# Altium schematic sheet microcontroller.SchDoc(U_microcontroller)
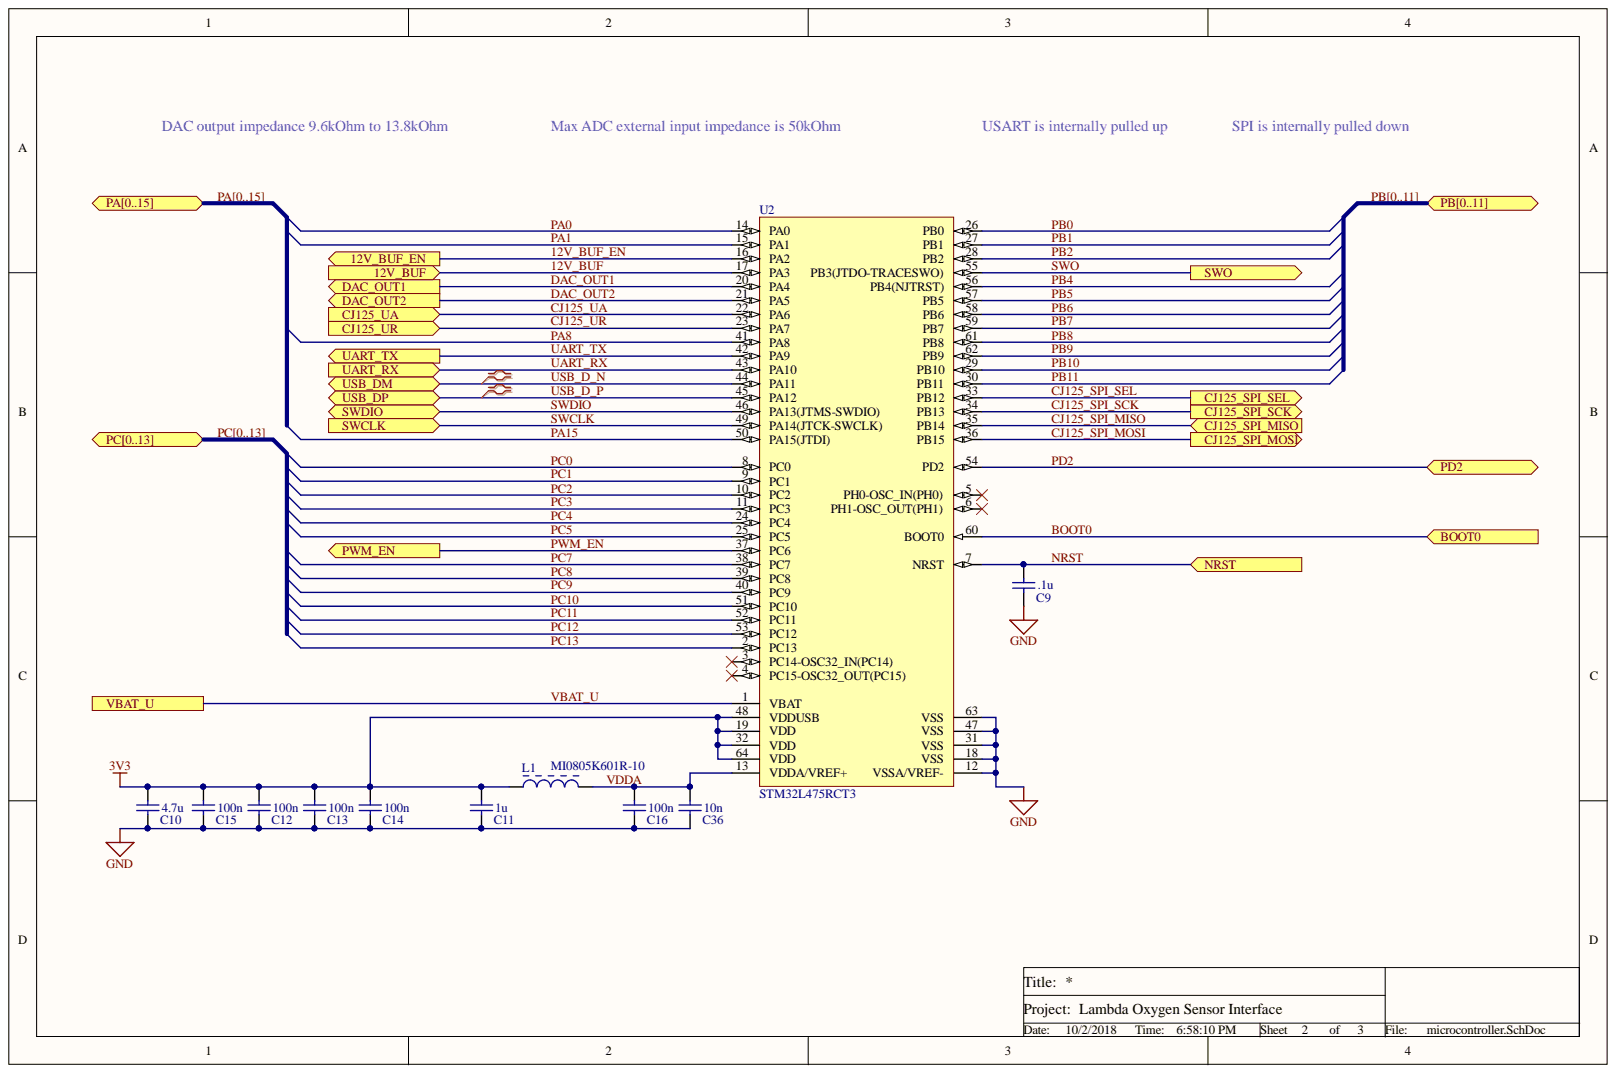
[diagram p0: microcontroller.SchDoc]

## Parts
C9  value .1u  [0805]  (hidden comment: Generic 0805 Capacitor)
C10  value 4.7u  [0805]  (hidden comment: Generic 0805 Capacitor)
C11  value 1u  [0805]  (hidden comment: Generic 0805 Capacitor)
C12  value 100n  [0805]  (hidden comment: Generic 0805 Capacitor)
C13  value 100n  [0805]  (hidden comment: Generic 0805 Capacitor)
C14  value 100n  [0805]  (hidden comment: Generic 0805 Capacitor)
C15  value 100n  [0805]  (hidden comment: Generic 0805 Capacitor)
C16  value 100n  [0805]  (hidden comment: Generic 0805 Capacitor)
C36  value 10n  [0805]  (hidden comment: Generic 0805 Capacitor)
L1  MI0805K601R-10  [LIRD-MI0805K601R-10-2_V]
U2  STM32L475RCT3  [STM-LQFP64_L]

## Ports
12V_BUF, 12V_BUF_EN, BOOT0, CJ125_SPI_MISO, CJ125_SPI_MOSI, CJ125_SPI_SCK, CJ125_SPI_SEL, CJ125_UA, CJ125_UR, DAC_OUT1, DAC_OUT2, NRST, PA0, PA1, PA2, PA3, PA4, PA5, PA6, PA7, PA8, PA9, PA10, PA11, PA12, PA13, PA14, PA15, PA[0..15], PB0, PB1, PB2, PB3, PB4, PB5, PB6, PB7, PB8, PB9, PB10, PB11, PB[0..11], PC0, PC1, PC2, PC3, PC4, PC5, PC6, PC7, PC8, PC9, PC10, PC11, PC12, PC13, PC[0..13], PD2, PWM_EN, SWCLK, SWDIO, SWO, UART_RX, UART_TX, USB_DM, USB_DP, VBAT_U

## Nets
3V3: C10.2 C11.2 C12.2 C13.2 C14.2 C15.2 L1.1 U2.19(VDD) U2.32(VDD) U2.48(VDDUSB) U2.64(VDD)
GND: C9.1 C10.1 C11.1 C12.1 C13.1 C14.1 C15.1 C16.1 C36.1 U2.12(VSSA/VREF-) U2.18(VSS) U2.31(VSS) U2.47(VSS) U2.63(VSS)
12V_BUF: U2.17(PA3) ; port 12V_BUF
12V_BUF_EN: U2.16(PA2) ; port 12V_BUF_EN
BOOT0: U2.60(BOOT0) ; port BOOT0
CJ125_SPI_MISO: U2.35(PB14) ; port CJ125_SPI_MISO
CJ125_SPI_MOSI: U2.36(PB15) ; port CJ125_SPI_MOSI
CJ125_SPI_SCK: U2.34(PB13) ; port CJ125_SPI_SCK
CJ125_SPI_SEL: U2.33(PB12) ; port CJ125_SPI_SEL
CJ125_UA: U2.22(PA6) ; port CJ125_UA
CJ125_UR: U2.23(PA7) ; port CJ125_UR
DAC_OUT1: U2.20(PA4) ; port DAC_OUT1
DAC_OUT2: U2.21(PA5) ; port DAC_OUT2
NRST: C9.2 U2.7(NRST) ; port NRST
PA0: U2.14(PA0) ; port PA[0..15]  [also labelled PA]
PA1: U2.15(PA1) ; port PA[0..15]  [also labelled PA]
PA8: U2.41(PA8) ; port PA[0..15]  [also labelled PA]
PA15: U2.50(PA15(JTDI)) ; port PA[0..15]  [also labelled PA]
PB0: U2.26(PB0) ; port PB[0..11]  [also labelled PB]
PB1: U2.27(PB1) ; port PB[0..11]  [also labelled PB]
PB2: U2.28(PB2) ; port PB[0..11]  [also labelled PB]
PB4: U2.56(PB4(NJTRST)) ; port PB[0..11]  [also labelled PB]
PB5: U2.57(PB5) ; port PB[0..11]  [also labelled PB]
PB6: U2.58(PB6) ; port PB[0..11]  [also labelled PB]
PB7: U2.59(PB7) ; port PB[0..11]  [also labelled PB]
PB8: U2.61(PB8) ; port PB[0..11]  [also labelled PB]
PB9: U2.62(PB9) ; port PB[0..11]  [also labelled PB]
PB10: U2.29(PB10) ; port PB[0..11]  [also labelled PB]
PB11: U2.30(PB11) ; port PB[0..11]  [also labelled PB]
PC0: U2.8(PC0) ; port PC[0..13]  [also labelled PC]
PC1: U2.9(PC1) ; port PC[0..13]  [also labelled PC]
PC2: U2.10(PC2) ; port PC[0..13]  [also labelled PC]
PC3: U2.11(PC3) ; port PC[0..13]  [also labelled PC]
PC4: U2.24(PC4) ; port PC[0..13]  [also labelled PC]
PC5: U2.25(PC5) ; port PC[0..13]  [also labelled PC]
PC7: U2.38(PC7) ; port PC[0..13]  [also labelled PC]
PC8: U2.39(PC8) ; port PC[0..13]  [also labelled PC]
PC9: U2.40(PC9) ; port PC[0..13]  [also labelled PC]
PC10: U2.51(PC10) ; port PC[0..13]  [also labelled PC]
PC11: U2.52(PC11) ; port PC[0..13]  [also labelled PC]
PC12: U2.53(PC12) ; port PC[0..13]  [also labelled PC]
PC13: U2.2(PC13) ; port PC[0..13]  [also labelled PC]
PD2: U2.54(PD2) ; port PD2
PWM_EN: U2.37(PC6) ; port PWM_EN
SWCLK: U2.49(PA14(JTCK-SWCLK)) ; port SWCLK
SWDIO: U2.46(PA13(JTMS-SWDIO)) ; port SWDIO
SWO: U2.55(PB3(JTDO-TRACESWO)) ; port SWO
UART_RX: U2.43(PA10) ; port UART_RX
UART_TX: U2.42(PA9) ; port UART_TX
USB_D_N: U2.44(PA11) ; port USB_DM
USB_D_P: U2.45(PA12) ; port USB_DP
VBAT_U: U2.1(VBAT) ; port VBAT_U
VDDA: C16.2 C36.2 L1.2 U2.13(VDDA/VREF+)
PA2: ; port PA[0..15]  [also labelled PA]
PA3: ; port PA[0..15]  [also labelled PA]
PA4: ; port PA[0..15]  [also labelled PA]
PA5: ; port PA[0..15]  [also labelled PA]
PA6: ; port PA[0..15]  [also labelled PA]
PA7: ; port PA[0..15]  [also labelled PA]
PA9: ; port PA[0..15]  [also labelled PA]
PA10: ; port PA[0..15]  [also labelled PA]
PA11: ; port PA[0..15]  [also labelled PA]
PA12: ; port PA[0..15]  [also labelled PA]
PA13: ; port PA[0..15]  [also labelled PA]
PA14: ; port PA[0..15]  [also labelled PA]
PB3: ; port PB[0..11]  [also labelled PB]
PC6: ; port PC[0..13]  [also labelled PC]

Not connected: U2 3(PC14-OSC32_IN(PC14)) 4(PC15-OSC32_OUT(PC15)) 5(PH0-OSC_IN(PH0)) 6(PH1-OSC_OUT(PH1))

## Library data of the parts
C9-C16 C36 = C_0805: Library Name: symbol_library.SchLib; Description: Generic 0805 Capacitor; Component Kind: Standard
L1 = MI0805K: Chip Bead for Power Filtering, 1500 mA, 600 Ohm, -40 to 125 degC, 0805 (2012 Metric), RoHS, Tape and Reel; Manufacturer: Laird; Other Names: 240-2390-1; Online Catalog: MISeries; Number of Lines: 1; Kits: 240-2227-ND-KITEMIFERRITEBEADCHIPSMD; Impedance @ Frequency: 600Ohm@100MHz; Filter Type: PowerLine; DC Resistance (DCR) (Max): 100mOhm; Current Rating (Max): 1.5A; Catalog Drawings: (HI,HZ,LI,MI)0805Series_1.10mmMax.Height; Standard Package: 1; Size / Dimension: 0.079"Lx0.049"W(2.00mmx1.25mm); Series: MI; Ratings: -; Packaging: CutTape(CT); Package Reference: MI0805K601R-10-2; Package Description: 2-Pin Surface Mount Device, Body 2 x 1.25 mm; Package / Case: 0805(2012Metric); Operating Temperature: -40degC~125degC; Mounting Type: SurfaceMount; Manufacturer URL: https://www.lairdtech.com; Library Name: symbol_library.SchLib; Height (Max): 0.043"(1.10mm); Family: FerriteBeadsandChips; Datasheet Version: Rev. A, 01/2004; Datasheet URL: https://datasheet.ciiva.com/3082/mi0805k601r-10-a-vp-3082219.pdf; Component Kind: Standard; Category: Filters
U2 = STM32L475RCT3: Ultra-Low-Power ARM Cortex-M4 32-bit MCU+FPU, 256 KB Flash, 128 KB Internal RAM, 51 I/Os, 64-Pin LQFP, -40 to 125 degC, Tray; Supply Voltage(Vcc) min (V): 1.71; Operating Frequency(F) (Processor speed) (MHz): 80; Internal RAM Size (kB): 128; I/Os (High Current): 51; FLASH Size (Prog) (kB): 256; D/A Converter: 2; Supplier Part Number 1: 497-16840-ND; Supplier 1: Digi-Key; Packing: Tray; Package Description: 64-Pin Low-profile Quad Flat Package, Body 10 x 10 mm, Pitch 0.5 mm; Package: LQFP64; Mounting Technology: Surface Mount; Library Name: symbol_library.SchLib; DatasheetVersion: Rev. 4; Core: ARM Cortex-M4; ComponentLink1URL: http://www.st.com/content/ccc/resource/technical/document/datasheet/90/51/73/d2/53/60/4f/5f/DM00172872.pdf/files/DM00172872.pdf/jcr:content/translations/en.DM00172872.pdf; ComponentLink1Description: Datasheet; Component Kind: Standard
